# Altium schematic sheet Heaters.SchDoc(Heaters)
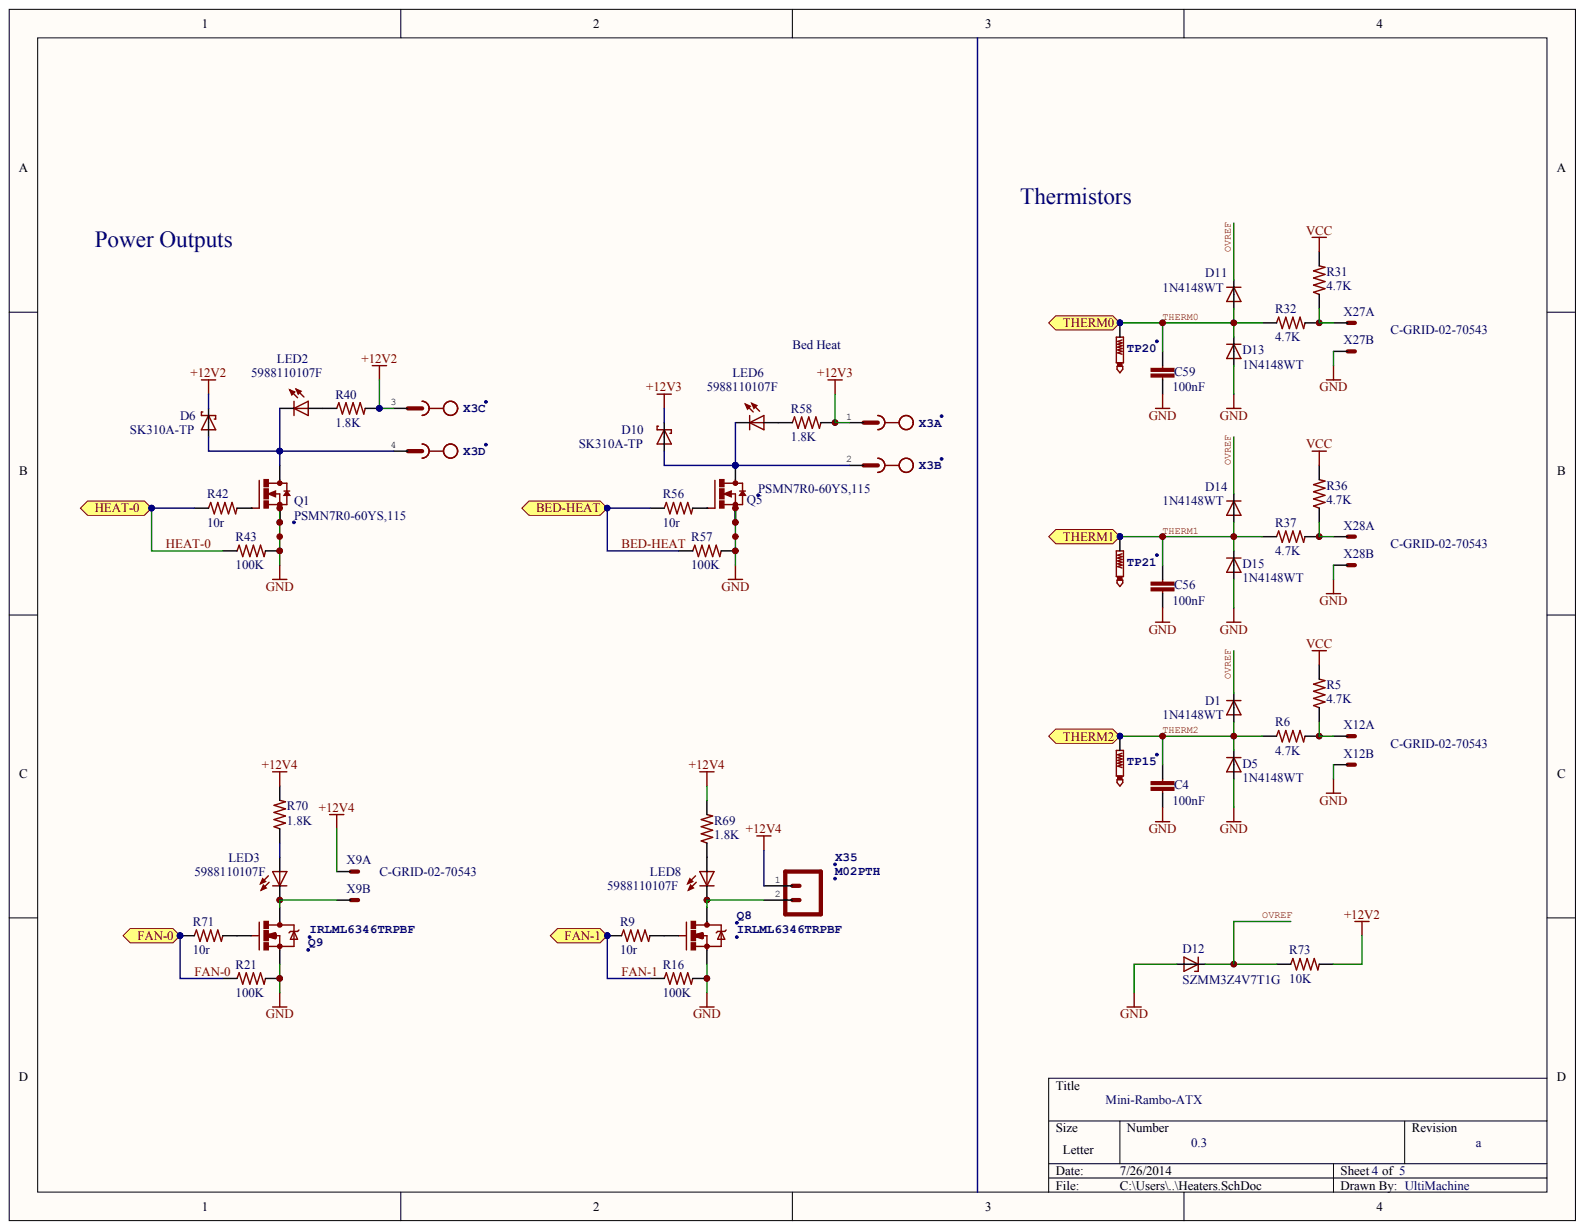
[diagram p0: Heaters.SchDoc]

## Parts
C4  100nF
C56  100nF
C59  100nF
D1  1N4148WT
D5  1N4148WT
D6  SK310A-TP
D10  SK310A-TP
D11  1N4148WT
D12  SZMM3Z4V7T1G
D13  1N4148WT
D14  1N4148WT
D15  1N4148WT
LED2  5988110107F
LED3  5988110107F
LED6  5988110107F
LED8  5988110107F
Q1  PSMN7R0-60YS,115
Q5  PSMN7R0-60YS,115
Q8  IRLML6346TRPBF
Q9  IRLML6346TRPBF
R5  4.7K
R6  4.7K
R9  10r
R16  100K
R21  100K
R31  4.7K
R32  4.7K
R36  4.7K
R37  4.7K
R40  1.8K
R42  10r
R43  100K
R56  10r
R57  100K
R58  1.8K
R69  1.8K
R70  1.8K
R71  10r
R73  10K
TP15  TestPoint  (value not drawn)
TP20  TestPoint  (value not drawn)
TP21  TestPoint  (value not drawn)
X3  0395311004  (value not drawn)  (parts A,B,C,D)
X9  C-GRID-02-70543  (parts A,B)
X12  C-GRID-02-70543  (parts A,B)
X27  C-GRID-02-70543  (parts A,B)
X28  C-GRID-02-70543  (parts A,B)
X35  M02PTH

## Ports
BED-HEAT, FAN-0, FAN-1, HEAT-0, THERM0, THERM1, THERM2

## Nets
+12V2: D6.C R40.2 R73.2 X3.3
+12V3: D10.C R58.2 X3.1
+12V4: R69.2 R70.2 X9.2 X35.1
GND: C4.2 C56.2 C59.2 D5.A D12.A D13.A D15.A Q1.1 Q1.2 Q1.3 Q5.1 Q5.2 Q5.3 Q8.2 Q9.2 R16.2 R21.2 R43.2 R57.2 X12.1 X27.1 X28.1
VCC: R5.2 R31.2 R36.2
BED-HEAT: R56.1 R57.1 ; port BED-HEAT
FAN-0: R21.1 R71.1 ; port FAN-0
FAN-1: R9.1 R16.1 ; port FAN-1
HEAT-0: R42.1 R43.1 ; port HEAT-0
OVREF: D1.C D11.C D12.C D14.C R73.1
THERM0: C59.1 D11.A D13.C R32.1 TP20.TP ; port THERM0
THERM1: C56.1 D14.A D15.C R37.1 TP21.TP ; port THERM1
THERM2: C4.1 D1.A D5.C R6.1 TP15.TP ; port THERM2
NetD6_A: D6.A LED2.C Q1.MB X3.4
NetD10_A: D10.A LED6.C Q5.MB X3.2
NetLED2_A: LED2.A R40.1
NetLED3_A: LED3.A R70.1
NetLED3_C: LED3.C Q9.3 X9.1
NetLED6_A: LED6.A R58.1
NetLED8_A: LED8.A R69.1
NetLED8_C: LED8.C Q8.3 X35.2
NetQ1_4: Q1.4 R42.2
NetQ5_4: Q5.4 R56.2
NetQ8_1: Q8.1 R9.2
NetQ9_1: Q9.1 R71.2
NetR5_1: R5.1 R6.2 X12.2
NetR31_1: R31.1 R32.2 X27.2
NetR36_1: R36.1 R37.2 X28.2
N00024:

## Library data of the parts
C4 C56 C59 = (no symbol name): ManufacturerPartNumber: GRM155R71C104KA88D; LastRevisionNote: ImportComponents; Published: 23-Apr-2014; LastRevisionDate: 23-Apr-2014; VALUE: 100nF; Publisher: UltiMachine
D1 D5 D11 D13-D15 LED2 LED3 LED6 LED8 Q1 Q5 Q8 Q9 TP15 TP20 TP21 X3 X9 X12 X27 X28 X35 = (no symbol name): LastRevisionNote: ImportComponents; Published: 23-Apr-2014; LastRevisionDate: 23-Apr-2014; Publisher: UltiMachine
  D1 D5 D11 D13-D15: Value: 1N4148WT
  LED2 LED3 LED6 LED8: VALUE: 5988110107F
  Q1 Q5: ManufacturerPartNumber: PSMN7R0-60YS,115
  Q8 Q9: ManufacturerPartNumber: IRLML6346TRPBF
  X3: ManufacturerPartNumber: 0395311004
D6 D10 = (no symbol name): ManufacturerPartNumber: SK310A; LastRevisionNote: ImportComponents; LastRevisionDate: 23-Apr-2014; Published: 23-Apr-2014; Publisher: UltiMachine
D12 = (no symbol name): Manufacturer: Fairchild; LastRevisionNote: ImportComponents; Published: 23-Apr-2014; LastRevisionDate: 23-Apr-2014; Publisher: UltiMachine
R5 R6 R31 R32 R36 R37 = (no symbol name): ManufacturerPartNumber: ERJ3EKF4701V; LastRevisionNote: ImportComponents; Published: 23-Apr-2014; LastRevisionDate: 23-Apr-2014; VALUE: 4.7K; Publisher: UltiMachine
R9 R42 R56 R71 = (no symbol name): ManufacturerPartNumber: CRCW060310R0FKEA; LastRevisionNote: ImportComponents; Published: 23-Apr-2014; LastRevisionDate: 23-Apr-2014; VALUE: 10r; Publisher: UltiMachine
R16 R21 R43 R57 = (no symbol name): ManufacturerPartNumber: RC0603FR-07100KL; LastRevisionNote: ImportComponents; Published: 23-Apr-2014; LastRevisionDate: 23-Apr-2014; VALUE: 100K; Publisher: UltiMachine
R40 R58 R69 R70 = (no symbol name): ManufacturerPartNumber: RC0603JR-071K8L; LastRevisionNote: ImportComponents; Published: 23-Apr-2014; LastRevisionDate: 23-Apr-2014; VALUE: 1.8K; Publisher: UltiMachine
R73 = (no symbol name): ManufacturerPartNumber: ERJ-3EKF1002V; LastRevisionNote: ImportComponents; Published: 23-Apr-2014; LastRevisionDate: 23-Apr-2014; VALUE: 10K; Publisher: UltiMachine
